# Altium schematic sheet Shield.SchDoc(Shield)
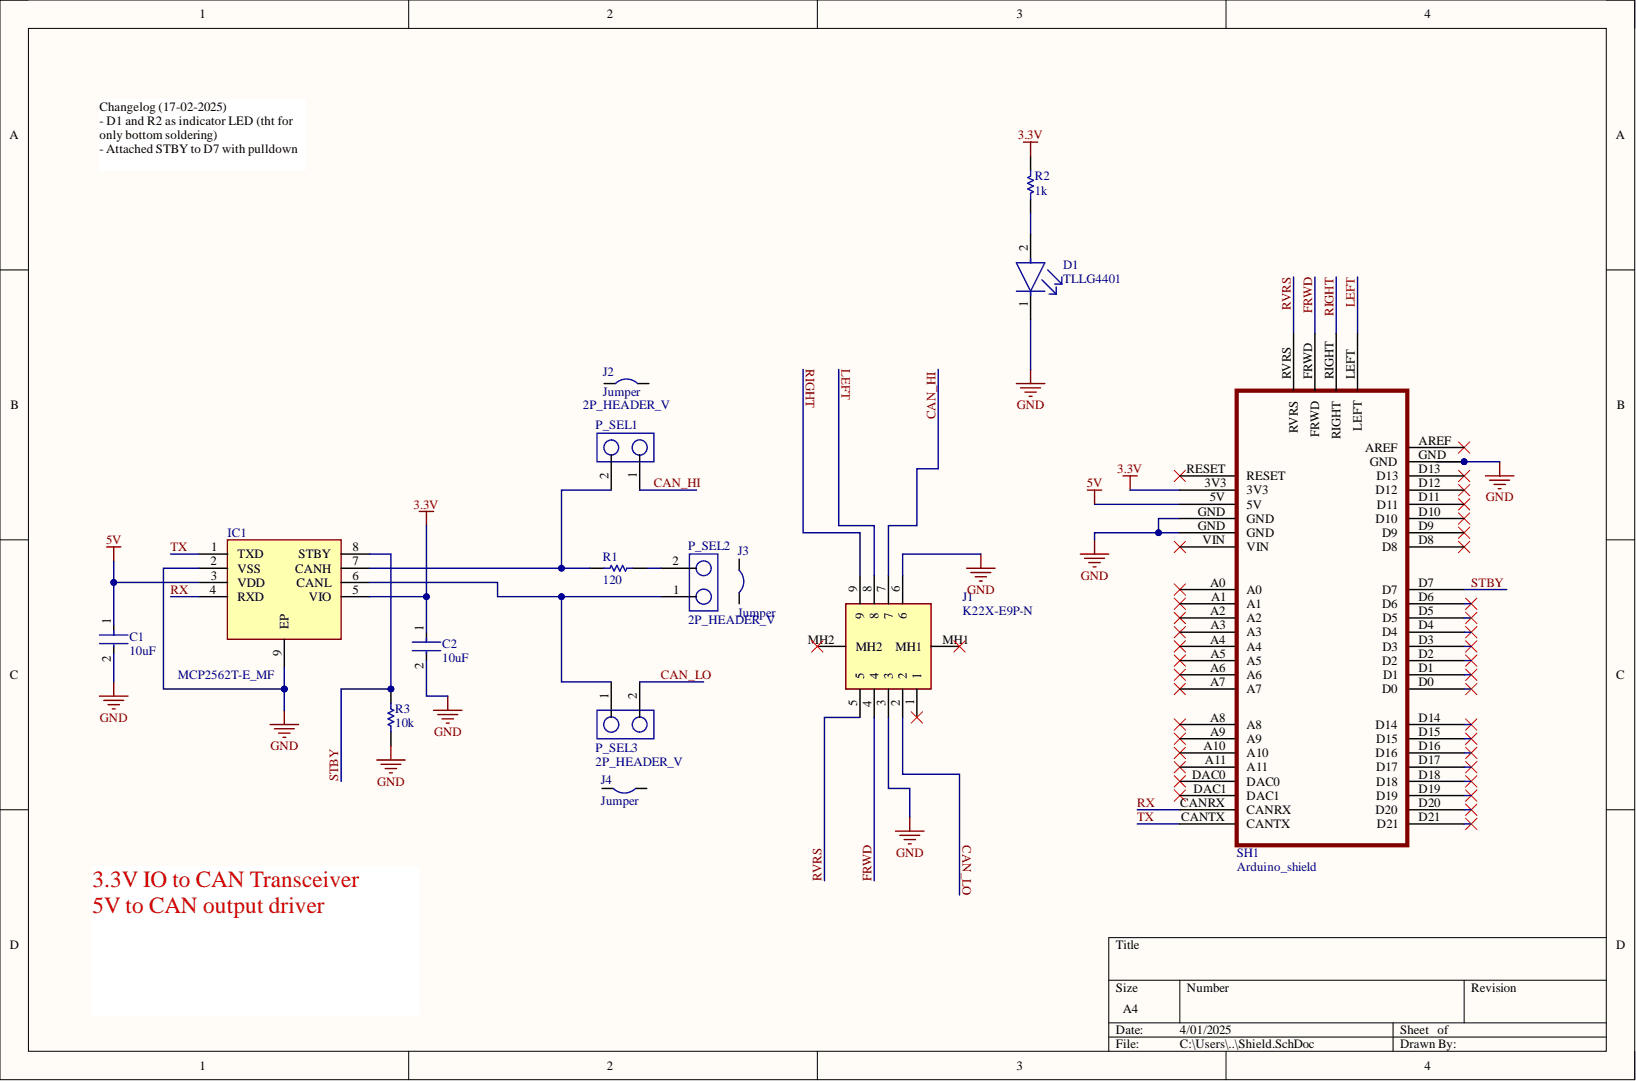
[diagram p0: Shield.SchDoc]

## Parts
C1  10uF  [C0402]
C2  10uF  [C0402]
D1  TLLG4401  [FP-6_544-5255_01-4-MFG]
IC1  MCP2562T-E_MF  [SON65P300X300X100-9N-D]
J1  K22X-E9P-N  [K22XE9PN]
J2  Jumper  (mechanical)
J3  Jumper  (mechanical)
J4  Jumper  (mechanical)
P_SEL1  2P_HEADER_V  [2PIN_HEADER]
P_SEL2  2P_HEADER_V  [2PIN_HEADER]
P_SEL3  2P_HEADER_V  [2PIN_HEADER]
R1  120  [R0402]
R2  1k  [R0402]
R3  10k  [R0402]
SH1  Arduino_shield  [Arduino_R1_shield]  (no BOM)

## Nets
3.3V: C2.1 IC1.5(VIO) R2.1 SH1.3V3
5V: C1.1 IC1.3(VDD) SH1.5V
GND: C1.2 C2.2 D1.1 IC1.2(VSS) IC1.9(EP) J1.3 J1.6 R3.1 SH1.GND
CAN_HI: J1.7 P_SEL1.1
CAN_LO: J1.2 P_SEL3.2
FRWD: J1.4 SH1.FRWD
LEFT: J1.8 SH1.LEFT
RIGHT: J1.9 SH1.RIGHT
RVRS: J1.5 SH1.RVRS
RX: IC1.4(RXD) SH1.CANRX
STBY: IC1.8(STBY) R3.2 SH1.D7
TX: IC1.1(TXD) SH1.CANTX
NetD1_2: D1.2 R2.2
NetIC1_6: IC1.6(CANL) P_SEL2.1 P_SEL3.1
NetIC1_7: IC1.7(CANH) P_SEL1.2 R1.1
NetP_SEL2_2: P_SEL2.2 R1.2

Not connected: J1 1 MH1 MH2; SH1 A0 A1 A2 A3 A4 A5 A6 A7 A8 A9 A10 A11 AREF D0 D1 D2 D3 D4 D5 D6 D8 D9 D10 D11 D12 D13 D14 D15 D16 D17 D18 D19 D20 D21 DAC0 DAC1 RESET VIN

## Library data of the parts
C1 C2 = b4654b650e69147ec39a6b967a45e02: Dielectric: X5R; Tolerance: 10%; Voltage Rating: 10V; Capacitance: 10uF; Case/Package: 0603; Component Kind: Standard; Depth: 0.8mm; Height: 0.8mm; Lead Free: Lead Free; Length: 1.6mm; Library Name: Schlib1.SchLib; Max Operating Temperature: 85°C; Min Operating Temperature: -55°C; Mount: Surface Mount; Package Quantity: 10000; Packaging: Tape and Reel; Radiation Hardening: No; Thickness: 0.035inch; Voltage Rating (DC): 10V; Width: 0.031inch
D1 = CMP-00030-39390728-1: TLLG4401 LED, Green, 2mcd, 3mmDia., T-1, +/-25deg, 565nm, 7mA, 1.9V Vf, 20V Vr, 20mW, -40; Dominant Wavelength: 575nm; Forward Current: 2mA; Lead Length: 23mm; Lens Style: Circular, Colored, Diffused, Dome, Round, Tinted; Lens Transparency: Diffused; Max Junction Temperature (Tj): 100°C; Number of Elements: 1; Reverse Voltage: 6V; Viewing Angle: 25°; Voltage Rating (DC): 2.4V; Ambient Temperature Range High: 100°C; Case/Package: Radial; Color: Green; Component Kind: Standard; Depth: 3.2mm; Forward Voltage: 1.9V; Height: 4.5mm; Illumination Color: Green; Lead Free: Lead Free; Length: 3.2mm; Lens Color: Green; Library Name: Altium Content Vault; Luminous Intensity: 2mcd; Max Operating Temperature: 100°C; Min Operating Temperature: -40°C; Mount: Through Hole; NexarPartId: 39390728; Number of LEDs: 1; Number of Pins: 2; Packaging: Bulk; Peak Wavelength: 565nm; Power Dissipation: 20mW; Radiation Hardening: No; REACH SVHC: Unknown; RoHS: Compliant; Schedule B: 8541402000, 8541402000; Test Current: 2mA; Wavelength: 575nm
IC1 = MCP2562T-E_MF: Integrated Circuit; Manufacturer_Name: Microchip; Manufacturer_Part_Number: MCP2562T-E/MF; Arrow Part Number: MCP2562T-E/MF; Arrow Price/Stock: https://www.arrow.com/en/products/mcp2562t-emf/microchip-technology?region=nac; Component Kind: Standard; Datasheet Link: http://www.microchip.com/mymicrochip/filehandler.aspx?ddocname=en561044; Height: 1mm; Mouser Part Number: 579-MCP2562T-E/MF; Mouser Price/Stock: https://www.mouser.co.uk/ProductDetail/Microchip-Technology/MCP2562T-E-MF?qs=eTPcRhULBzwxTukF3PQfjw%3D%3D
J1 = K22X-E9P-N: Connector; Manufacturer_Name: Kycon; Component Kind: Standard; Datasheet Link: https://www.mouser.com/datasheet/2/222/K22X-334802.pdf; Height: 12.55mm; Manufacturer_Part_Number: K22X-E9P-N; Mouser Part Number: 806-K22X-E9P-N; Mouser Price/Stock: https://www.mouser.co.uk/ProductDetail/Kycon/K22X-E9P-N?qs=gotfk7Nc9iLI4pqBVl59WQ%3D%3D
J2-J4 = NPC02SXON-RC: Library Name: Schlib1.SchLib; Supplier 1: Digikey; Supplier Part Number 1: S9341-ND
P_SEL1-P_SEL3 = 2P_HEADER_V: 3M; 929647-01-02, HEADER 2-PIN Vertical PC Mount.; Unit_Price_Static: 0.02; Component Kind: Standard; Library Name: Schlib1.SchLib; Manufacturer: 3M; MANUFACTURER PART NUMBER: 929647-01-02; PACKAGE / CASE: 2Pin_HEADER_V; Supplier 1: Digikey; Supplier Part Number 1: 952-1770-ND; Text: ?
R1-R3 = RES: Manufacturer: Panasonic; Part Number: ERJ-2RKF66R5X; Component Kind: Standard; Library Name: Schlib1.SchLib
SH1 = Arduino_R1_Shield: Library Name: Schlib1.SchLib
